# Altium schematic sheet Sheet1.SchDoc
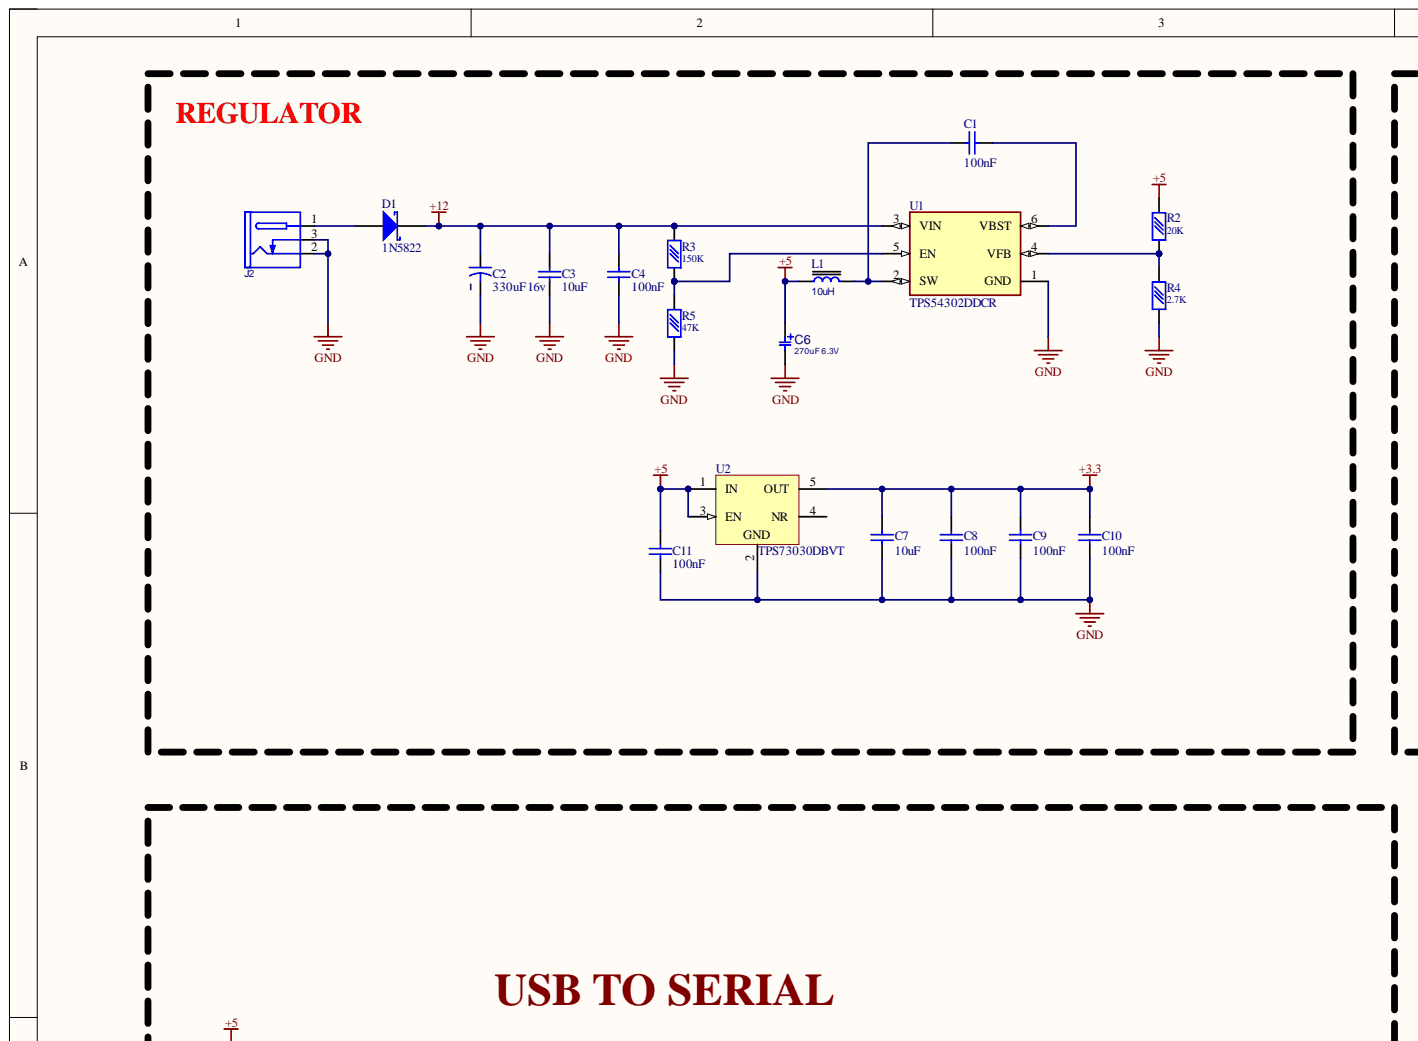
[diagram p0: Sheet1.SchDoc, top left]
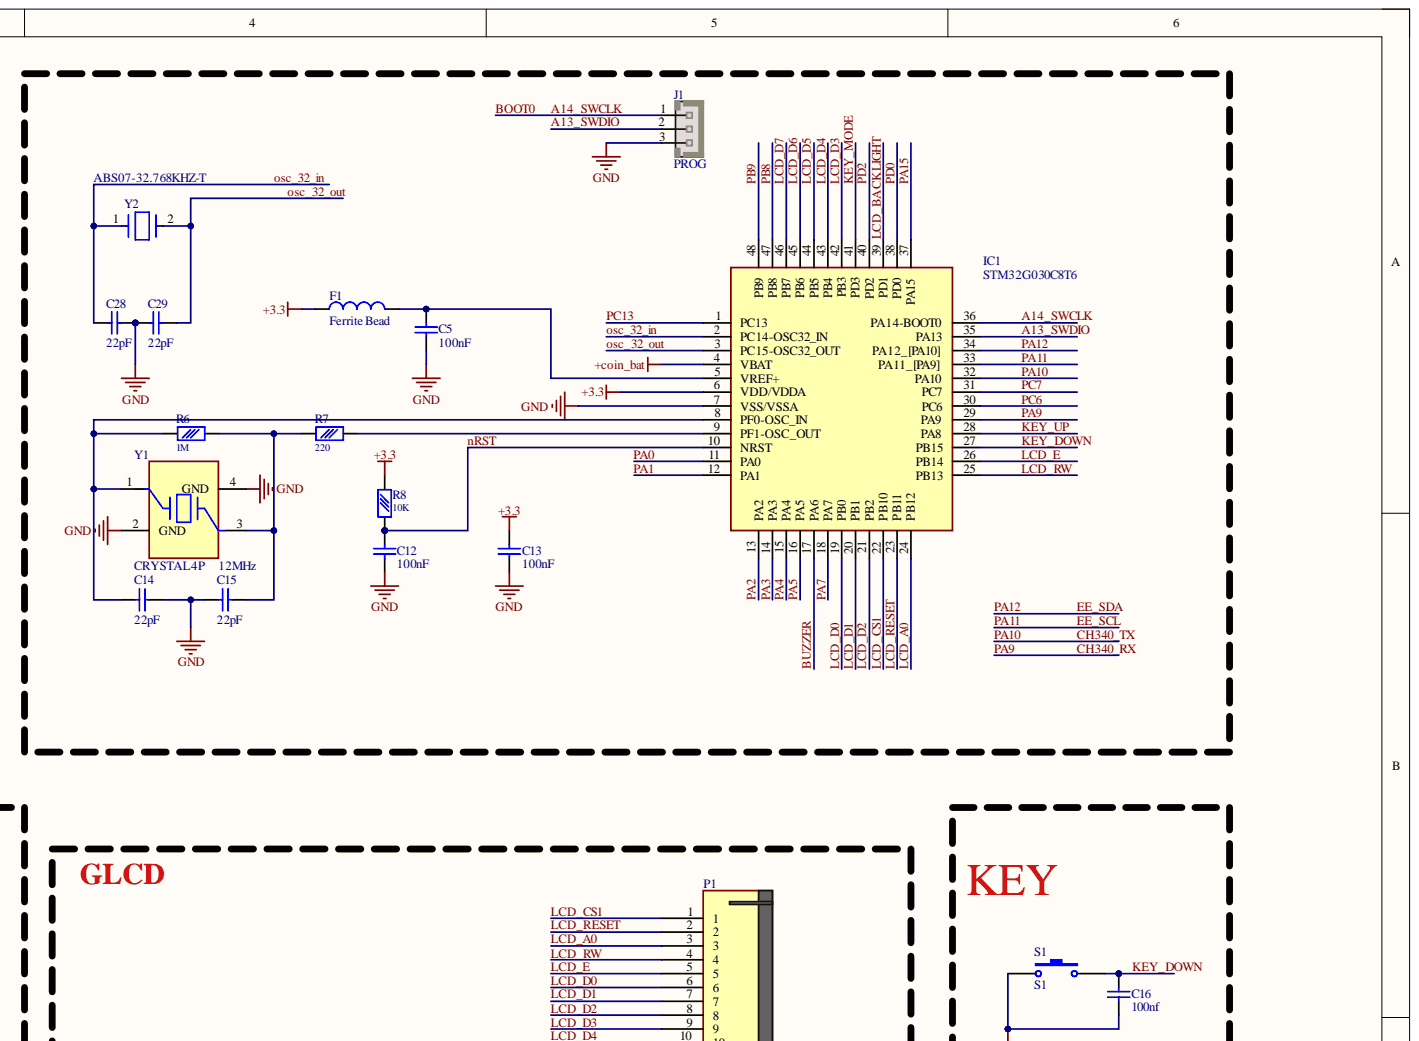
[diagram p1: Sheet1.SchDoc, top right]
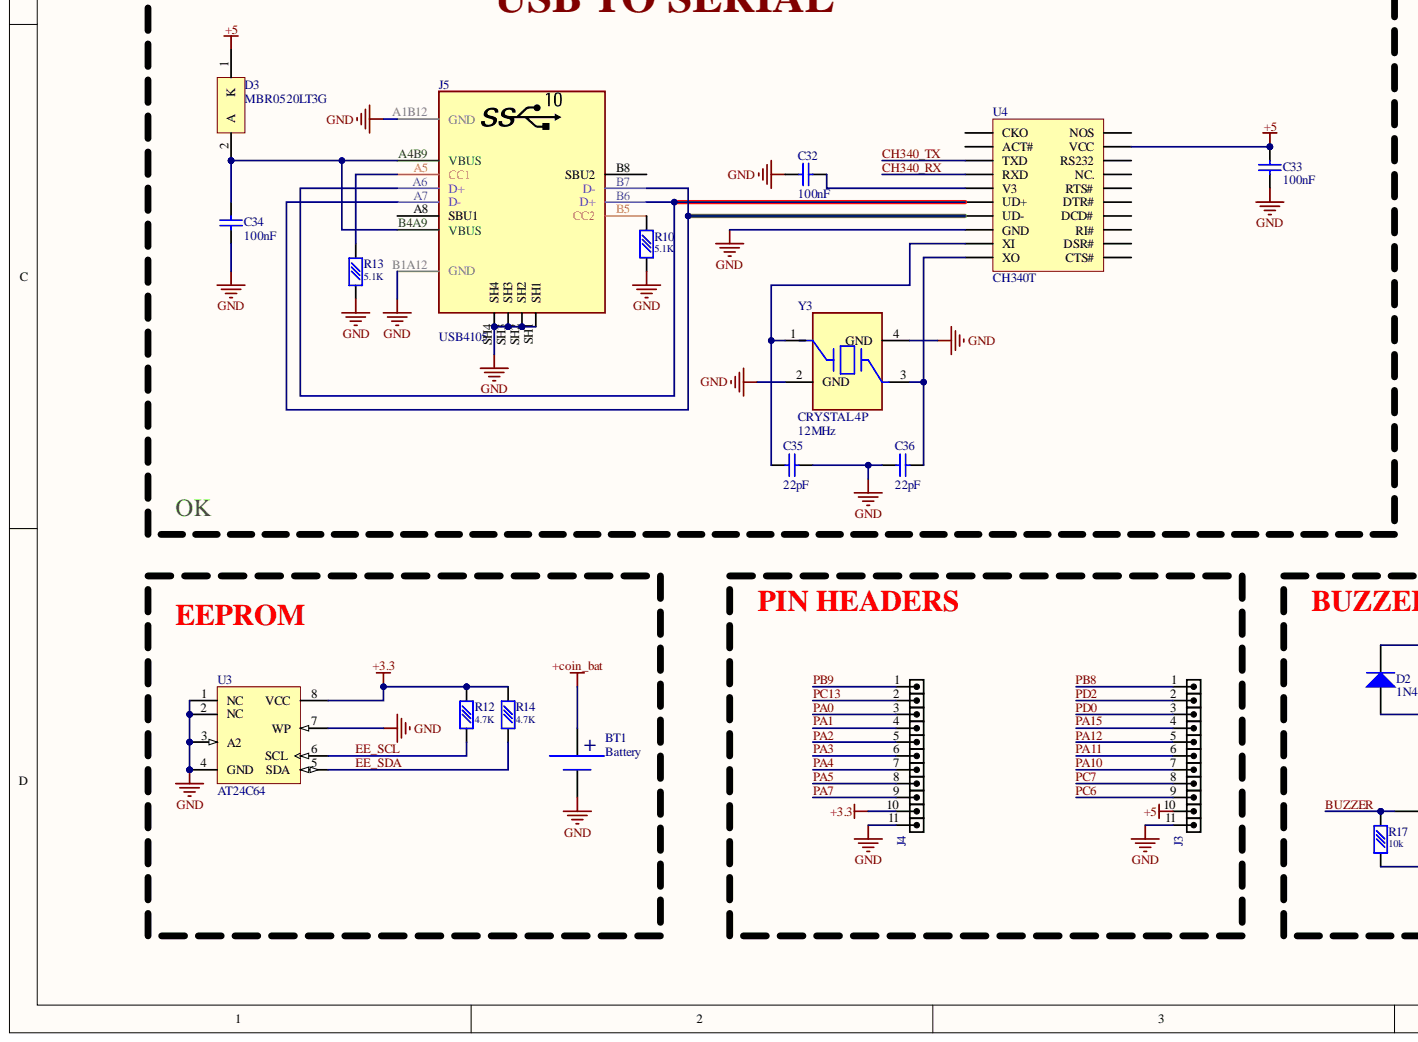
[diagram p2: Sheet1.SchDoc, bottom left]
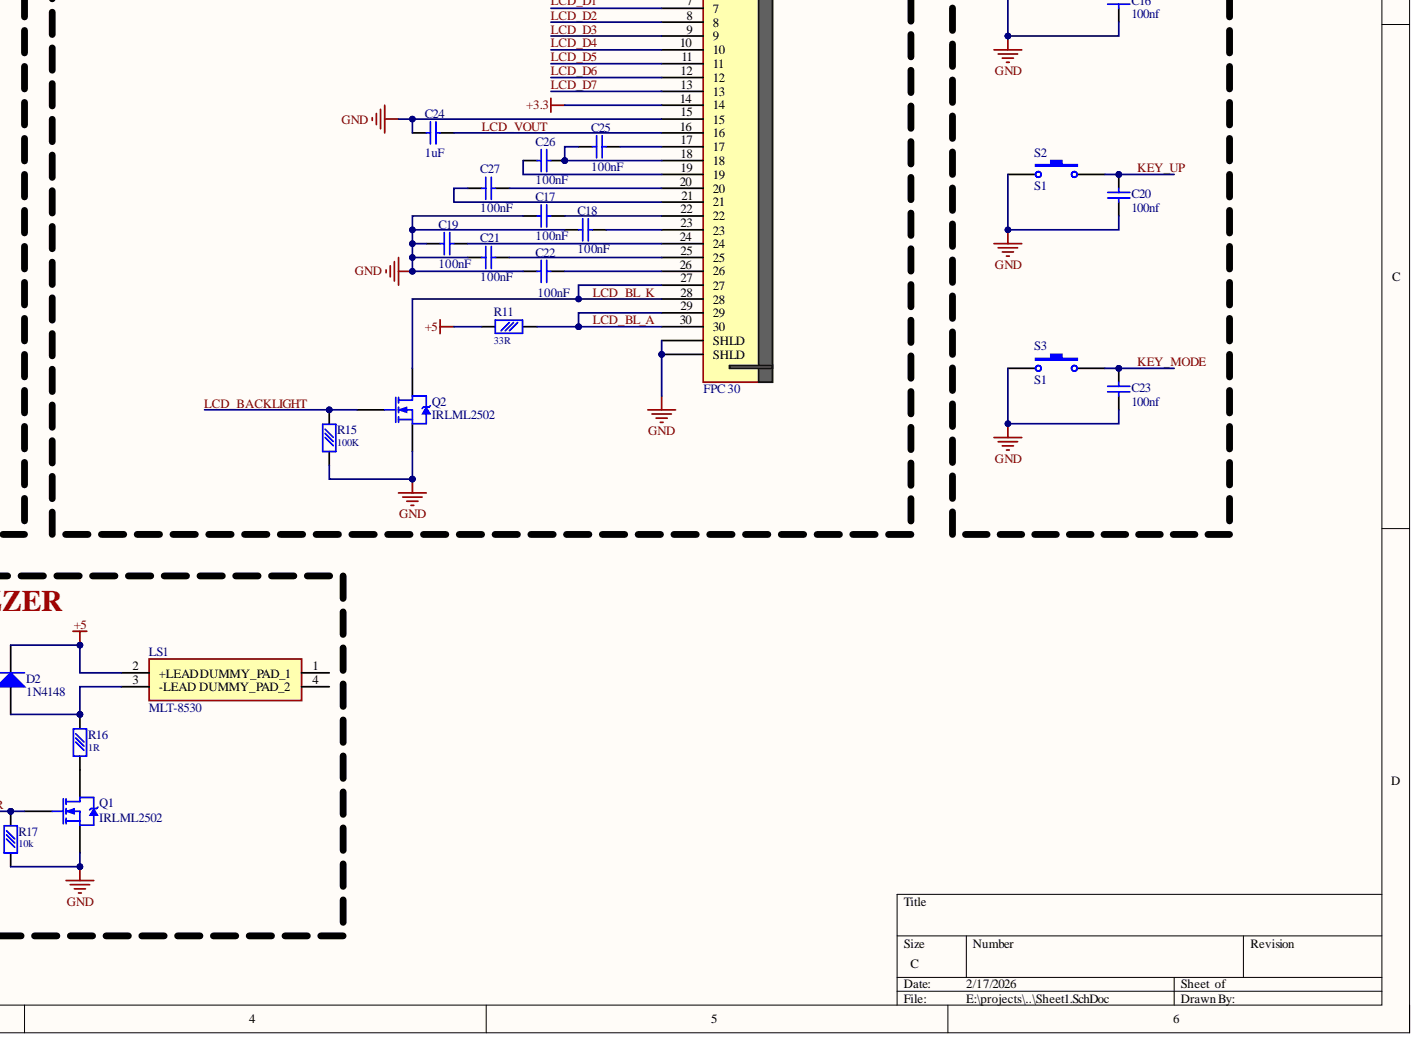
[diagram p3: Sheet1.SchDoc, bottom right]

## Parts
BT1  Battery  [CR1220]
C1  value 100nF  [CAP 0805]  (hidden comment: chip_cap)
C2  value 330uF 16v  [CAP-RADIAL-3.2mm]  (hidden comment: CAP)
C3  value 10uF  [CAP 1206/3216 - 1.0MM]  (hidden comment: chip_cap)
C4  value 100nF  [CAP 0805]  (hidden comment: chip_cap)
C5  value 100nF  [CAP 0805]  (hidden comment: chip_cap)
C6  270uF 6.3V  [C_SOLID_5X7]
C7  value 10uF  [CAP 1206/3216 - 1.0MM]  (hidden comment: chip_cap)
C8  value 100nF  [CAP 0805]  (hidden comment: chip_cap)
C9  value 100nF  [CAP 0805]  (hidden comment: chip_cap)
C10  value 100nF  [CAP 0805]  (hidden comment: chip_cap)
C11  value 100nF  [CAP 0805]  (hidden comment: chip_cap)
C12  value 100nF  [CAP 0805]  (hidden comment: chip_cap)
C13  value 100nF  [CAP 0805]  (hidden comment: chip_cap)
C14  value 22pF  [CAP 0603/1608]  (hidden comment: chip_cap)
C15  value 22pF  [CAP 0603/1608]  (hidden comment: chip_cap)
C16  value 100nf  [CAP 0805]  (hidden comment: chip_cap)
C17  value 100nF  [CAP 0805]  (hidden comment: chip_cap)
C18  value 100nF  [CAP 0805]  (hidden comment: chip_cap)
C19  value 100nF  [CAP 0805]  (hidden comment: chip_cap)
C20  value 100nf  [CAP 0805]  (hidden comment: chip_cap)
C21  value 100nF  [CAP 0805]  (hidden comment: chip_cap)
C22  value 100nF  [CAP 0805]  (hidden comment: chip_cap)
C23  value 100nf  [CAP 0805]  (hidden comment: chip_cap)
C24  value 1uF  [CAP 0805]  (hidden comment: chip_cap)
C25  value 100nF  [CAP 0805]  (hidden comment: chip_cap)
C26  value 100nF  [CAP 0805]  (hidden comment: chip_cap)
C27  value 100nF  [CAP 0805]  (hidden comment: chip_cap)
C28  value 22pF  [CAP 0805]  (hidden comment: chip_cap)
C29  value 22pF  [CAP 0805]  (hidden comment: chip_cap)
C32  value 100nF  [CAP 0805]  (hidden comment: chip_cap)
C33  value 100nF  [CAP 0805]  (hidden comment: chip_cap)
C34  value 100nF  [CAP 0805]  (hidden comment: chip_cap)
C35  value 22pF  [CAP 0603/1608]  (hidden comment: chip_cap)
C36  value 22pF  [CAP 0603/1608]  (hidden comment: chip_cap)
D1  1N5822  [DIOM5028X20N]
D2  1N4148  [DIOMELF4017N]
D3  MBR0520LT3G  [ONSC-SOD-123-2-425-04_V]
F1  Ferrite Bead  [RES 0805]
IC1  STM32G030C8T6  [QFP50P900X900X160-48N]
J1  PROG  [XH_3]
J2  DC_POWER_JACK  [JAC_PWR2]  (value not drawn)
J3  HDR1X11  [HDR_M_1X11]  (value not drawn)
J4  HDR1X11  [HDR_M_1X11]  (value not drawn)
J5  USB4105  [USBC_SMD_16_USB4105_B]
L1  10uH  [L_4]
LS1  MLT-8530  [MLT8530]
P1  FPC 30  [FPC-30P-0.5MM]
Q1  IRLML2502  [SOT-23_L]
Q2  IRLML2502  [SOT-23_L]
R2  value 20K  [RES 0603]  (hidden comment: chip_res)
R3  value 150K  [RES 0603]  (hidden comment: chip_res)
R4  value 2.7K  [RES 0603]  (hidden comment: chip_res)
R5  value 47K  [RES 0603]  (hidden comment: chip_res)
R6  value 1M  [RES 0603]  (hidden comment: chip_res)
R7  value 220  [RES 0603]  (hidden comment: chip_res)
R8  value 10K  [RES 0805]  (hidden comment: chip_res)
R10  value 5.1K  [RES 0603]  (hidden comment: chip_res)
R11  value 33R  [RES 2512]  (hidden comment: chip_res)
R12  value 4.7K  [RES 0805]  (hidden comment: chip_res)
R13  value 5.1K  [RES 0603]  (hidden comment: chip_res)
R14  value 4.7K  [RES 0805]  (hidden comment: chip_res)
R15  value 100K  [RES 0603]  (hidden comment: chip_res)
R16  value 1R  [RES 1206]  (hidden comment: chip_res)
R17  value 10k  [RES 0805]  (hidden comment: chip_res)
S1  S1  [tactile-micro-push-button]
S2  S1  [tactile-micro-push-button]
S3  S1  [tactile-micro-push-button]
U1  TPS54302DDCR  [FP-DDC0006A-IPC_B]
U2  TPS73030DBVT  [SOT23-5_N]
U3  AT24C64  [8S1_N]
U4  CH340T  [SSOP20_L]
Y1  CRYSTAL 4P  value 12MHz  [XTL_4_3225]
Y2  ABS07-32.768KHZ-T  [FP-ABS07-MFG]
Y3  CRYSTAL 4P  value 12MHz  [XTL_4_3225]

## Nets
+3.3: C7.2 C8.2 C9.2 C10.2 C13.2 F1.1 IC1.6(VDD/VDDA) J4.10 P1.14 R8.2 R12.2 R14.2 U2.5(OUT) U3.8(VCC)
+5: C6.1 C11.2 C33.1 D2.2 D3.1 J3.10 L1.1 LS1.2(+LEAD) R2.2 R11.1 U2.1(IN) U2.3(EN) U4.19(VCC)
+12: C2.1 C3.2 C4.2 D1.2 R3.2 U1.3(VIN)
+coin_bat: BT1.2 IC1.4(VBAT)
GND: BT1.1 C2.2 C3.1 C4.1 C5.1 C6.2 C7.1 C8.1 C9.1 C10.1 C11.1 C12.1 C13.1 C14.1 C15.2 C16.1 C17.1 C18.1 C19.1 C20.1 C21.1 C22.1 C23.1 C24.1 C28.1 C29.2 C32.2 C33.2 C34.1 C35.2 C36.1 IC1.7(VSS/VSSA) J1.3 J2.2 J2.3 J3.11 J4.11 J5.A1B12(GND) J5.B1A12(GND) J5.SH1 J5.SH2 J5.SH3 J5.SH4 P1.15 P1.31(SHLD) P1.32(SHLD) Q1.2 Q2.2 R4.1 R5.1 R10.1 R13.1 R15.1 R17.1 S1.1 S2.1 S3.1 U1.1(GND) U2.2 U3.1(NC) U3.2(NC) U3.3(A2) U3.4(GND) U3.7(WP) U4.8(GND) Y1.2(GND) Y1.4(GND) Y3.2(GND) Y3.4(GND)
A13_SWDIO: IC1.35(PA13) J1.2
A14_SWCLK: IC1.36(PA14-BOOT0) J1.1
BUZZER: IC1.17(PA6) Q1.1 R17.2
CH340_RX: IC1.29(PA9) U4.4(RXD)
CH340_TX: IC1.32(PA10) J3.7 U4.3(TXD)
EE_SCL: IC1.33(PA11_[PA9]) J3.6 R12.1 U3.6(SCL)
EE_SDA: IC1.34(PA12_[PA10]) J3.5 R14.1 U3.5(SDA)
KEY_DOWN: C16.2 IC1.27(PB15) S1.2
KEY_MODE: C23.2 IC1.41(PD3) S3.2
KEY_UP: C20.2 IC1.28(PA8) S2.2
LCD_A0: IC1.24(PB12) P1.3
LCD_BACKLIGHT: IC1.39(PD1) Q2.1 R15.2
LCD_BL_A: P1.29 P1.30 R11.2
LCD_BL_K: P1.27 P1.28 Q2.3
LCD_CS1: IC1.22(PB10) P1.1
LCD_D0: IC1.19(PB0) P1.6
LCD_D1: IC1.20(PB1) P1.7
LCD_D2: IC1.21(PB2) P1.8
LCD_D3: IC1.42(PB3) P1.9
LCD_D4: IC1.43(PB4) P1.10
LCD_D5: IC1.44(PB5) P1.11
LCD_D6: IC1.45(PB6) P1.12
LCD_D7: IC1.46(PB7) P1.13
LCD_E: IC1.26(PB14) P1.5
LCD_RESET: IC1.23(PB11) P1.2
LCD_RW: IC1.25(PB13) P1.4
LCD_VOUT: C24.2 P1.16
nRST: C12.2 IC1.10(NRST) R8.1
osc_32_in: C28.2 IC1.2(PC14-OSC32_IN) Y2.1
osc_32_out: C29.1 IC1.3(PC15-OSC32_OUT) Y2.2
PA0: IC1.11(PA0) J4.3
PA1: IC1.12(PA1) J4.4
PA2: IC1.13(PA2) J4.5
PA3: IC1.14(PA3) J4.6
PA4: IC1.15(PA4) J4.7
PA5: IC1.16(PA5) J4.8
PA7: IC1.18(PA7) J4.9
PA15: IC1.37(PA15) J3.4
PB8: IC1.47(PB8) J3.1
PB9: IC1.48(PB9) J4.1
PC6: IC1.30(PC6) J3.9
PC7: IC1.31(PC7) J3.8
PC13: IC1.1(PC13) J4.2
PD0: IC1.38(PD0) J3.3
PD2: IC1.40(PD2) J3.2
NetC1_1: C1.1 L1.2 U1.2(SW)
NetC1_2: C1.2 U1.6(VBST)
NetC5_2: C5.2 F1.2 IC1.5(VREF+)
NetC14_2: C14.2 IC1.8(PF0-OSC_IN) R6.2 Y1.1
NetC15_1: C15.1 R6.1 R7.2 Y1.3
NetC17_2: C17.2 P1.22
NetC18_2: C18.2 P1.23
NetC19_2: C19.2 P1.24
NetC21_2: C21.2 P1.25
NetC22_2: C22.2 P1.26
NetC25_1: C25.1 C26.2 P1.18
NetC25_2: C25.2 P1.17
NetC26_1: C26.1 P1.19
NetC27_1: C27.1 P1.21
NetC27_2: C27.2 P1.20
NetC32_1: C32.1 U4.5(V3)
NetC34_2: C34.2 D3.2 J5.A4B9(VBUS) J5.B4A9(VBUS)
NetC35_1: C35.1 U4.9(XI) Y3.1
NetC36_2: C36.2 U4.10(XO) Y3.3
NetD1_1: D1.1 J2.1
NetD2_1: D2.1 LS1.3(-LEAD) R16.2
NetIC1_9: IC1.9(PF1-OSC_OUT) R7.1
NetJ5_A5: J5.A5(CC1) R13.2
NetJ5_A6: J5.A6(D+) J5.B6(D+) U4.6(UD+)
NetJ5_A7: J5.A7(D-) J5.B7(D-) U4.7(UD-)
NetJ5_B5: J5.B5(CC2) R10.2
NetQ1_3: Q1.3 R16.1
NetR2_1: R2.1 R4.2 U1.4(VFB)
NetR3_1: R3.1 R5.2 U1.5(EN)
USB TO SERIAL:

Not connected: J5 A8(SBU1) B8(SBU2); LS1 1(DUMMY_PAD_1) 4(DUMMY_PAD_2); U2 4(NR); U4 1(CKO) 2(ACT#) 11(CTS#) 12(DSR#) 13(RI#) 14(DCD#) 15(DTR#) 16(RTS#) 17(NC.) 18(RS232) 20(NOS)

## Library data of the parts
BT1 = Battery holder: Designer: Seyed Mohsen Ghasemian; E-mail: picpars@gmail.com; Component Kind: Standard; ComponentLinkURL: www.picpars.com; Description: Battery holder; Make: 02/12/2011; Library Name: Schlibrary.SchLib
C1 C3-C5 C7-C29 C32-C36 = chip_cap: Capacitor (Semiconductor SIM Model); Component Kind: Standard; LatestRevisionDate: 29-May-2009; LatestRevisionNote: IPC-7351 Footprint Added.; PackageDescription: Chip Capacitor; PackageReference: C1206; Published: 8-Jun-2000; Publisher: Altium Limited
  C1 C3 C4 C7-C11 C16-C27 C32-C36: Library Name: @mylib_passive.IntLib
  C5 C12-C15 C28 C29: Library Name: Schlibrary.SchLib
C2 = CAP RADIAL: Value: 330uF 16v; Component Kind: Standard; Library Name: @mylib_passive.IntLib
C6 = CAP_THD_SOLID_5X7: CAP, THD, ELEC,; DESC: DESCRIPTION; DIST#: MOUSER; MANF_P#: MANF_PART#; PARAM: 20%; PARAM2: 25V; ASSEMBLY: ASSEMBLY; Component Kind: Standard; Library Name: @mylib_passive.IntLib; MANF: MANF; PRICE: 10= 5$; VALUE: 270uF 6.3V
D1 = 1N5822: Silicon RF Schottky Diode for Mixer Applications in the VHF/UHF Range; Component Kind: Standard; Library Name: @my diode.IntLib; PackageReference: SMC; PackageVersion: Sep-2001; Published: 21-Mar-2003; Publisher: Altium Limited
D2 = 1N4148: Zener Diode; Component Kind: Standard; LatestRevisionDate: 17-Jul-2002; LatestRevisionNote: Re-released for DXP Platform.; Library Name: @my diode.IntLib; PackageDescription: Diode, Thru-Hole; 2 Leads; 0.7 in Pin Spacing; PackageReference: DIODE-0.7; Published: 8-Jun-2000; Publisher: Altium Limited
D3 = CMP-1055-00408-1: Schottky Power Rectifier, 2-Pin SOD-123, Pb-Free, Tape and Reel; Manufacturer: On Semiconductor; Configuration: Single; IFSM Max (A): 5.5; Component Kind: Standard; ComponentLink1Description: Manufacturer URL; ComponentLink1URL: http://www.onsemi.com/; ComponentLink2Description: Datasheet; ComponentLink2URL: http://www.onsemi.cn/pub_link/Collateral/MBR0520LT1-D.PDF; ComponentLink3Description: Package Specification; ComponentLink3URL: http://www.onsemi.com/pub_link/Collateral/425-04.PDF; DatasheetVersion: Rev. 6; Library Name: Altium Content Vault; Mounting Technology: Surface Mount; PackageDescription: 2-Pin SOD, Body 1.6 x 2.69 mm; PackageReference: SOD-123-2-425-04; PackageVersion: Rev. G, 10/2009; Packing: Tape and Reel; PartNumber: MBR0520LT3G; RoHS: TRUE
F1 = Ferrite Bead: Code_IEC: 1005; Code_JEITA: 0402; Component Kind: Standard; Description: Ferrite Bead; LatestRevisionDate: 29-May-2009; LatestRevisionNote: IPC-7351 Footprint Added.; PackageDescription: Chip Inductor; PackageReference: 0402-A; Published: 8-Jun-2000; Library Name: Schlibrary.SchLib; Publisher: Altium Limited
IC1 = STM32G030C8T6: Manufacturer_Name: STMicroelectronics; Arrow Part Number: STM32G030C8T6; Arrow Price/Stock: https://www.arrow.com/en/products/stm32g030c8t6/stmicroelectronics?region=nac; Component Kind: Standard; Datasheet Link: https://componentsearchengine.com/Datasheets/1/STM32G030C8T6.pdf; Description: STM32G030C8T6; Height: 1.6mm; Library Name: STM32_F7_H7_MP1.IntLib; Manufacturer_Part_Number: STM32G030C8T6; Mouser Part Number: 511-STM32G030C8T6; Mouser Price/Stock: https://www.mouser.co.uk/ProductDetail/STMicroelectronics/STM32G030C8T6?qs=uwxL4vQweFMKBA8wRRkiWA%3D%3D
J1 = XH_3: PW10-x-M, JST-BxB-XH-A pitch: 2.5mm, 3A/250v; MANF: JST; MANF_P#: B3B-XH-A; PARAM: 3.0A; PARAM2: 250v; Component Kind: Standard; Library Name: conn_wire2board.SchLib; VALUE: PROG
J2 = CONN_PWR_JACKR2: CONN, PWR JACK DC, PLUG DIAM 2.0MM RA THD; MANF: CUI STACK; MANF_P#: PJ-202A, PJ-002AH, PJ-102AH, KLDX-0202; Component Kind: Standard; Library Name: conn_powerJack.SchLib; VALUE: DC_POWER_JACK
J3 J4 = HDR_M_1X11: CONN, HEADER, Male, pitch: 2.54mm, 11POS; VALUE: HDRM11; Component Kind: Standard; Library Name: conn_header_2.54mm_st.SchLib
J5 = USBC_SMD_16: CONN, USB, Type C, USB 2.0, Right-Angle, SMD; MANF: GCT; MANF_P#: USB4105-GF-A; PARAM: 5A; Component Kind: Standard; Library Name: @Connector.IntLib; Price: 1.5$; Value: USB4105
L1 = INDPWR_SMD: INDUCTOR, POWER, SMD; Component Kind: Standard; Library Name: passive_smd.SchLib; VALUE: 10uH
LS1 = MLT-8530: Loudspeaker or Buzzer; Manufacturer_Name: Jiangsu Huaneng Elec; Component Kind: Standard; Datasheet Link: https://datasheet.lcsc.com/szlcsc/1811182003_Jiangsu-Huaneng-Elec-MLT-8530_C94599.pdf; Height: 3mm; Library Name: @my_lib.IntLib; Manufacturer_Part_Number: MLT-8530
P1 = FPC 30: FPC, 30-Pin; Component Kind: Standard; LatestRevisionDate: 17-Jul-2002; LatestRevisionNote: Re-released for DXP Platform.; Library Name: @Connector.IntLib; Publisher: Altium Limited
Q1 Q2 = IRLML2502: HEXFET Power MOSFET; Component Kind: Standard; ComponentLink1Description: Manufacturer Link; ComponentLink1URL: http://www.irf.com/; ComponentLink2Description: Datasheet; ComponentLink2URL: http://www.irf.com/product-info/datasheets/data/irlml2402.pdf; DatasheetVersion: Aug-1997; LatestRevisionDate: 20-Nov-2009; LatestRevisionNote: IPC-7351 Footprint Added.; Library Name: @my-switch.IntLib; PackageDescription: 3-Pin SOT-23 Package 0.95 mm Pitch, 2.3 mm Lead Span; PackageReference: SOT-23; Published: 8-Jun-2000; Publisher: Altium Limited
R2-R8 R10-R17 = chip_res: Resistor; Component Kind: Standard; LatestRevisionDate: 29-May-2009; LatestRevisionNote: IPC-7351 Footprint Added.; PackageDescription: Chip Resistor; PackageReference: J1-0603; Published: 8-Jun-2000; Publisher: Altium Limited
  R2-R5 R10-R15: Library Name: @mylib_passive.IntLib
  R6-R8 R16 R17: Library Name: Schlibrary.SchLib
S1-S3 = tactile-micro-push-button: Switch; Component Kind: Standard; LatestRevisionDate: 17-Jul-2002; LatestRevisionNote: Re-released for DXP Platform.; Library Name: @my-switch.IntLib; PackageDescription: Switch; 2 Leads; PackageReference: SPST-2; Published: 24-Mar-1999; Publisher: Altium Limited
U1 = TPS562201DDCR: IC REG BUCK ADJ 2A TSOT23-6; Max Input Voltage: 17V; Min Input Voltage: 4.5V; Number of Outputs: 1; Output Type: Adjustable; Output Voltage: 7V; Quiescent Current: 400uA; Switching Frequency: 580kHz; Case/Package: SOT; Component Kind: Standard; Contact Plating: Tin; Lead Free: Lead Free; Library Name: @my-lib-regulator.IntLib; Max Operating Temperature: 125°C; Max Output Current: 2A; Min Operating Temperature: -40°C; Mount: Surface Mount; Number of Pins: 6; Operating Supply Voltage: 17V; RoHS Compliant: Yes
U2 = TPS73030DBVT: Single Output High PSRR LDO, 200 mA, Fixed 3 V Output, 2.7 to 5.5 V Input, 5-pin SOT-23 (DBV), -40 to 85 degC, Green (RoHS & no Sb/Br); Manufacturer: Texas Instruments; Accuracy(%): 2; Fixed Output Options(V): 3; Iout(Max)(A): 0.2; Iq(Typ)(mA): 0.17; Rating: Catalog; SubFamily: Single Channel LDO; Vdo(Typ)(mV): 120; Vin(Max)(V): 5.5; Vin(Min)(V): 2.7; Code_JEDEC: MO-178; Component Kind: Standard; ComponentLink1Description: Manufacturer URL; ComponentLink1URL: http://www.ti.com/; ComponentLink2Description: Datasheet; ComponentLink2URL: http://www.ti.com/general/docs/lit/getliterature.tsp?genericPartNumber=TPS73030&fileType=pdf; ComponentLink3Description: Package Specification; ComponentLink3URL: http://www.ti.com/litv/pdf/mpds018i; DatasheetVersion: SBVS054I; Eco-Plan: Green (RoHS & no Sb/Br); Library Name: @my_lib.IntLib; Mounting Technology: Surface Mount; PackageDescription: 5-Pin Plastic Small Outline, Body 1.60 أ— 2.90 mm, Pitch 0.95 mm; PackageReference: DBV5; PackageVersion: 4073253-4/K; PartNumber: TPS73030DBVT; Supplier 1: Digi-Key; Supplier 2: Digi-Key; Supplier 3: Digi-Key; Supplier 4: Farnell; Supplier 5: Farnell; Supplier 6: Newark; Supplier 7: Newark; Supplier 8: Mouser; Supplier Part Number 1: 296-17579-2-ND; Supplier Part Number 2: 296-17579-1-ND; Supplier Part Number 3: 296-17579-6-ND; Supplier Part Number 4: 1135394; Supplier Part Number 5: 1135394RL; Supplier Part Number 6: 71J8231; Supplier Part Number 7: 93K0907; Supplier Part Number 8: 595-TPS73030DBVT; Vout Adj(Max)(V): 3; Vout Adj(Min)(V): 3
U3 = AT24C08BN-SP25-T: 8Kbit (1024 x 8), 2-wire Bus Automotive Serial EEPROM with Write Protect, 2.5V, 8-Pin SOIC, Tape & Reel; Code_IPC: SOIC127P600-8; Code_JEDEC: MS-012-AA; Component Kind: Standard; ComponentLink1Description: Manufacturer URL; ComponentLink1URL: http://www.atmel.com/; ComponentLink2Description: Datasheet; ComponentLink2URL: http://www.atmel.com/dyn/resources/prod_documents/doc8517.pdf; DatasheetVersion: 8517C,  Jan-2009; Library Name: Atmel Serial EEPROM.IntLib; PackageDescription: 8-Lead (0.150" Wide Body), Plastic Gull Wing Small Outline (JEDEC SOIC); PackageReference: 8S1; PackageVersion: revC, Mar-2005
Y1 = CRYSTAL SMD 4P: CRYSTAL 4P 8PF SMD; ESR (Equivalent Series Resistance): 80R; Frequency Stability: 30ppm; Frequency Tolerance: 20ppm; Case/Package: SMD/SMT; Component Kind: Standard; Height: 0.035inch; Length: 0.126inch; Library Name: @my_lib.IntLib; Load Capacitance: 8pF; Max Operating Temperature: 85°C; Min Operating Temperature: -40°C; Mount: Surface Mount; Number of Pins: 4; Package Quantity: 3000; Packaging: Tape and Reel; Width: 0.098inch
Y2 = CMP-0447-00001-4: Crystal 32.768kHz ±20ppm (Tol) 12.5pF FUND 70kΩ 2-Pin CSMD T/R; ESR (Equivalent Series Resistance): 70kR; Case/Package: CSMD; Component Kind: Standard; Depth: 1.5mm; Frequency: 32.768kHz; Frequency Tolerance: 20ppm; Height: 0.9mm; Lead Free: Lead Free; Length: 3.2mm; Library Name: Altium Content Vault; Load Capacitance: 12.5pF; Max Operating Temperature: 85°C; Min Operating Temperature: -40°C; Number of Pins: 2; Operating Frequency: 32.768 kHz; Package Quantity: 3000; Packaging: Tape and Reel; Radiation Hardening: No; REACH SVHC: No SVHC; RoHS Compliant: Yes; Series: ABS07; Series Resistance: 70kR; Termination: SMD/SMT; Tolerance: 20ppm; Width: 1.5mm
Y3 = CRYSTAL SMD 4P: CRYSTAL 4P 8PF SMD; ESR (Equivalent Series Resistance): 80R; Frequency Stability: 30ppm; Frequency Tolerance: 20ppm; Value: 12MHz; Case/Package: SMD/SMT; Component Kind: Standard; Height: 0.035inch; Length: 0.126inch; Load Capacitance: 8pF; Max Operating Temperature: 85°C; Min Operating Temperature: -40°C; Mount: Surface Mount; Number of Pins: 4; Package Quantity: 3000; Packaging: Tape and Reel; Width: 0.098inch; Library Name: Schlibrary.SchLib
U4 = CH340T: Component Kind: Standard; Library Name: my_lib.IntLib
